# Altium schematic sheet Power.SchDoc(Power)
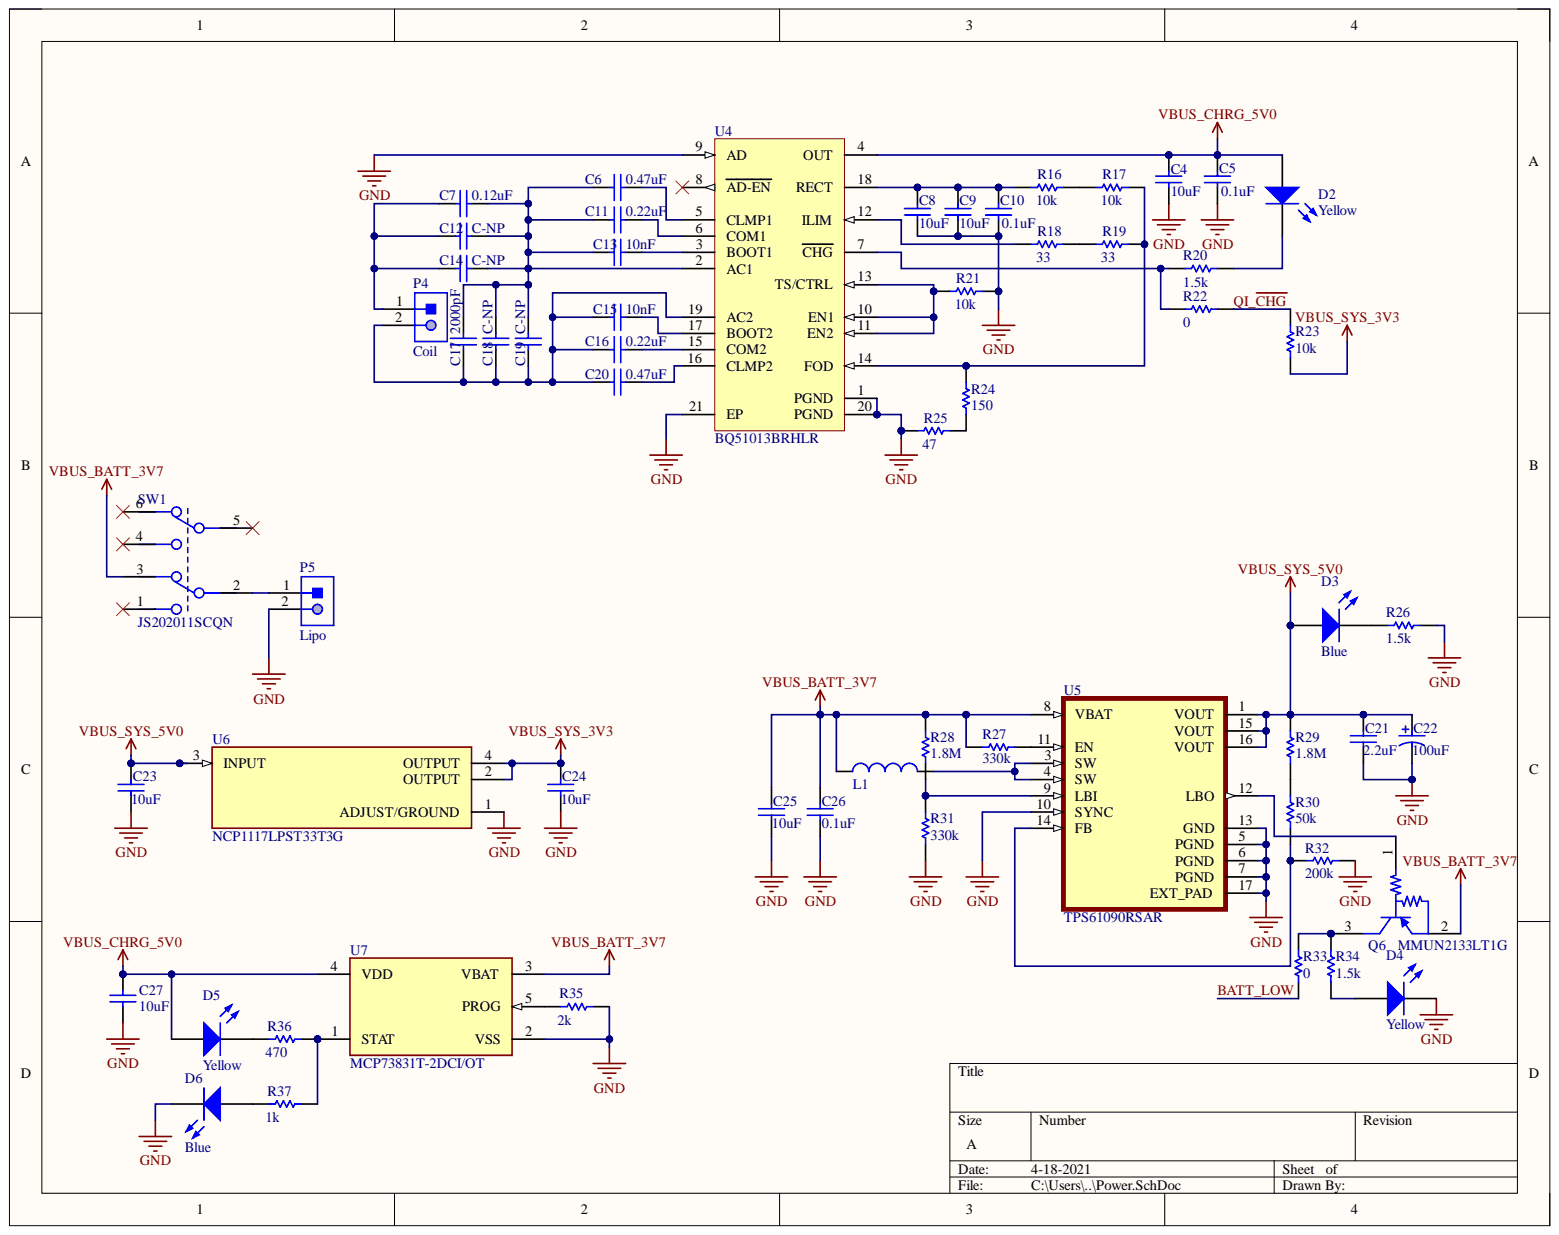
[diagram p0: Power.SchDoc]

## Parts
C4  10uF  [0603]
C5  0.1uF  [0603]
C6  0.47uF  [0603]
C7  0.12uF  [0603]
C8  10uF  [0603]
C9  10uF  [0603]
C10  0.1uF  [0603]
C11  0.22uF  [0603]
C12  C-NP  [0603]
C13  10nF  [0603]
C14  C-NP  [0603]
C15  10nF  [0603]
C16  0.22uF  [0603]
C17  2000pF  [0603]
C18  C-NP  [0603]
C19  C-NP  [0603]
C20  0.47uF  [0603]
C21  2.2uF  [0805]
C22  100uF  [PNSC-FP-V-C_V]
C23  10uF  [0805]
C24  10uF  [0805]
C25  10uF  [0805]
C26  0.1uF  [0805]
C27  10uF  [0805]
D2  Yellow  [1206_A_LED]
D3  Blue  [1206_A_LED]
D4  Yellow  [1206_A_LED]
D5  Yellow  [1206_A_LED]
D6  Blue  [1206_A_LED]
L1  MSS6132-472MLB  [COILCRAFT MSS6132 SERIES]  (value not drawn)
P4  Coil  [JST-S2B-PH-K-S_V]
P5  Lipo  [JST-S2B-PH-K-S_V]
Q6  MMUN2133LT1G  [ONSC-SOT-23-3-318-08_V]
R16  10k  [0603_RES_HD]
R17  10k  [0603_RES_HD]
R18  33  [0603_RES_HD]
R19  33  [0603_RES_HD]
R20  1.5k  [0603_RES_HD]
R21  10k  [0603_RES_HD]
R22  0  [0603_RES_HD]
R23  10k  [0603_RES_HD]
R24  150  [0603_RES_HD]
R25  47  [0603_RES_HD]
R26  1.5k  [0805_RES_HD]
R27  330k  [0805_RES_HD]
R28  1.8M  [0805_RES_HD]
R29  1.8M  [0805_RES_HD]
R30  50k  [0805_RES_HD]
R31  330k  [0805_RES_HD]
R32  200k  [0805_RES_HD]
R33  0  [0805_RES_HD]
R34  1.5k  [0805_RES_HD]
R35  2k  [0805_RES_HD]
R36  470  [0805_RES_HD]
R37  1k  [0805_RES_HD]
SW1  JS202011SCQN  [FP-JS202011SCQN-MFG]
U4  BQ51013BRHLR  [RHL0020A_V]
U5  TPS61090RSAR  [QFN65P400X400X100-17N]
U6  NCP1117LPST33T3G  [FP-318H-MFG]
U7  MCP73831T-2DCI/OT  [FP-C04-091-OT-IPC_B]

## Nets
GND: C4.1 C5.1 C8.1 C9.1 C10.1 C21.2 C22.2 C23.2 C24.2 C25.2 C26.2 C27.2 D4.1 D6.1 P5.2 R21.2 R25.1 R26.1 R31.1 R32.2 R35.2 U4.1(PGND) U4.9(AD) U4.20(PGND) U4.21(EP) U5.5(PGND) U5.6(PGND) U5.7(PGND) U5.10(SYNC) U5.13(GND) U5.17(EXT_PAD) U6.1(ADJUST/GROUND) U7.2(VSS)
VBUS_BATT_3V7: C25.1 C26.1 L1.1 Q6.2 R27.1 R28.2 SW1.3 U5.8(VBAT) U7.3(VBAT)
VBUS_CHRG_5V0: C4.2 C5.2 C27.1 D2.2 D5.2 U4.4(OUT) U7.4(VDD)
VBUS_SYS_3V3: C24.1 R23.1 U6.2(OUTPUT) U6.4(OUTPUT)
VBUS_SYS_5V0: C21.1 C22.1 C23.1 D3.2 R29.2 U5.1(VOUT) U5.15(VOUT) U5.16(VOUT) U6.3(INPUT)
BATT_LOW: R33.1
QI_~{CHG}: R22.1 R23.2
NetC6_1: C6.1 U4.5(CLMP1)
NetC6_2: C6.2 C7.1 C11.2 C12.1 C13.2 C14.1 C17.1 C18.1 C19.1 U4.2(AC1)
NetC7_2: C7.2 C12.2 C14.2 P4.1
NetC8_2: C8.2 C9.2 C10.2 R16.1 U4.18(RECT)
NetC11_1: C11.1 U4.6(COM1)
NetC13_1: C13.1 U4.3(BOOT1)
NetC15_1: C15.1 U4.17(BOOT2)
NetC15_2: C15.2 C16.2 C17.2 C18.2 C19.2 C20.2 P4.2 U4.19(AC2)
NetC16_1: C16.1 U4.15(COM2)
NetC20_1: C20.1 U4.16(CLMP2)
NetD2_1: D2.1 R20.1
NetD3_1: D3.1 R26.2
NetD4_2: D4.2 R34.1
NetD5_1: D5.1 R36.1
NetD6_2: D6.2 R37.1
NetL1_2: L1.2 U5.3(SW) U5.4(SW)
NetP5_1: P5.1 SW1.2
NetQ6_1: Q6.1 U5.12(LBO)
NetQ6_3: Q6.3 R33.2 R34.2
NetR16_2: R16.2 R17.1
NetR17_2: R17.2 R19.2 R24.2 U4.14(FOD)
NetR18_1: R18.1 U4.12(ILIM)
NetR18_2: R18.2 R19.1
NetR20_2: R20.2 R22.2 U4.7(CHG)
NetR21_1: R21.1 U4.10(EN1) U4.11(EN2) U4.13(TS/CTRL)
NetR24_1: R24.1 R25.2
NetR27_2: R27.2 U5.11(EN)
NetR28_1: R28.1 R31.2 U5.9(LBI)
NetR29_1: R29.1 R30.1
NetR30_2: R30.2 R32.1 U5.14(FB)
NetR35_1: R35.1 U7.5(PROG)
NetR36_2: R36.2 R37.2 U7.1(STAT)

Not connected: SW1 1 4 5 6; U4 8(AD-EN)

## Library data of the parts
C4 C8 C9 = C1608C0G1H361J: 10uF, 10%, 25V; Manufacturer 1: TDK; Database Table Name: capacitors; Component Kind: Standard; GFUPartNo: C1608C0G1H361J; Manufacturer Part Number 1: C1608C0G1H361J; PackageReference: 0603; Part Type: SMT; Supplier 1: Digi-Key; Supplier Part Number 1: 445-5067-1-ND; Library Name: AltiumGFU.SVNDbLib
C5 C10 = C1608C0G1H361J: 0.1uF, 10%, 50V; Manufacturer 1: TDK; Database Table Name: capacitors; Component Kind: Standard; GFUPartNo: C1608C0G1H361J; Manufacturer Part Number 1: C1608C0G1H361J; PackageReference: 0603; Part Type: SMT; Supplier 1: Digi-Key; Supplier Part Number 1: 445-5067-1-ND; Library Name: AltiumGFU.SVNDbLib
C6 C20 = C1608C0G1H361J: 0.47uF, 10%, 25V; Manufacturer 1: TDK; Database Table Name: capacitors; Component Kind: Standard; GFUPartNo: C1608C0G1H361J; Manufacturer Part Number 1: C1608C0G1H361J; PackageReference: 0603; Part Type: SMT; Supplier 1: Digi-Key; Supplier Part Number 1: 445-5067-1-ND; Library Name: AltiumGFU.SVNDbLib
C7 C12 C14 = C1608C0G1H361J: 0.12 uF, 10%, 25V; Manufacturer 1: TDK; Database Table Name: capacitors; Component Kind: Standard; GFUPartNo: C1608C0G1H361J; Manufacturer Part Number 1: C1608C0G1H361J; PackageReference: 0603; Part Type: SMT; Supplier 1: Digi-Key; Supplier Part Number 1: 445-5067-1-ND; Library Name: AltiumGFU.SVNDbLib
C11 C16 = C1608C0G1H361J: 0.22uF, 10%, 25V; Manufacturer 1: TDK; Database Table Name: capacitors; Component Kind: Standard; GFUPartNo: C1608C0G1H361J; Manufacturer Part Number 1: C1608C0G1H361J; PackageReference: 0603; Part Type: SMT; Supplier 1: Digi-Key; Supplier Part Number 1: 445-5067-1-ND; Library Name: AltiumGFU.SVNDbLib
C13 C15 = C1608C0G1H361J: 10nF, 10%, 25V; Manufacturer 1: TDK; Database Table Name: capacitors; Component Kind: Standard; GFUPartNo: C1608C0G1H361J; Manufacturer Part Number 1: C1608C0G1H361J; PackageReference: 0603; Part Type: SMT; Supplier 1: Digi-Key; Supplier Part Number 1: 445-5067-1-ND; Library Name: AltiumGFU.SVNDbLib
C17-C19 = C1608C0G1H361J: 2000 pF, 10%, 50V; Manufacturer 1: TDK; Database Table Name: capacitors; Component Kind: Standard; GFUPartNo: C1608C0G1H361J; Manufacturer Part Number 1: C1608C0G1H361J; PackageReference: 0603; Part Type: SMT; Supplier 1: Digi-Key; Supplier Part Number 1: 445-5067-1-ND; Library Name: AltiumGFU.SVNDbLib
C21 C23-C27 = CC0805KRX7R9BB152: 1.5nF, 10%, 50V; Manufacturer 1: Yageo; Database Table Name: capacitors; Component Kind: Standard; GFUPartNo: CC0805KRX7R9BB152; Manufacturer Part Number 1: CC0805KRX7R9BB152; PackageReference: 0805; Part Type: SMT; Supplier 1: Digi-Key; Supplier Part Number 1: 311-1128-1-ND; Library Name: AltiumGFU.SVNDbLib
C22 = CMP-2000-06213-1: EEE-HA Series 6.3 V 100 uF Ø 5 x 5.4 mm 105 °C SMT V-Chip Aluminum Electrolytic. EEE-HA0J101WR; Manufacturer: Panasonic; Capacitance: 22µF; ESR (Equivalent Series Resistance): 0.36O; Lifetime@Temperature: 2000Hrs@105°C; Rated Voltage: 25V; Ripple Current: 240mA; Type: Can; Case Size/Dimension: Ø5x5.8mm; Component Kind: Standard; Datasheet URL: http://industrial.panasonic.com/cdbs/www-data/pdf/RDE0000/ABA0000C1184.pdf; Datasheet Version: 03/2016; Library Name: Altium Content Vault; Manufacturer URL: http://www.panasonic.com/; Mounting Technology: SurfaceMount; Operating Temp Range: -55to+105°C; Operating Temp-Max: 105°C; Package Description: 2-Pin Electrolytic Aluminum Capacitor, Body 5.3 x 5.3 mm; Package Reference: FP-V-C; Package URL: http://industrial.panasonic.com/cdbs/www-data/pdf/RDE0000/DME0000COL48.pdf; Series: FP
D2-D6 = WE-LED_K1A2-2_Local: Component Kind: Standard; Library Name: WE-LED_K1A2-2.SchLib
L1 = MSS6132-472MLB: INDUCTOR POWER 4.7UH SHIELDED SMD; Manufacturer 1: Coilcraft; Current: 2.3A; Database Table Name: inductors; Shielded: YES; Tolerance: 20%; Component Kind: Standard; GFUPartNo: MSS6132-472MLB; Inductance: 4.7uH; Manufacturer Part Number 1: MSS6132-472MLB; Part Type: SMT; Supplier 1: Coilcraft; Supplier 2: Coilcraft; Supplier Order Qty 1: 1; Supplier Order Qty 2: 100; Supplier Part Number 1: MSS6132-472MLB; Supplier Part Number 2: MSS6132-472MLB; Supplier Unit Price 1: 0.94; Supplier Unit Price 2: 0.94; Library Name: AltiumGFU.SVNDbLib
P4 P5 = CMP-1755-00004-1: Male Header, Pitch 2 mm, 1 x 2 Position, Height 4.8 mm, Tail Length 3.4 mm, -25 to 85 degC, RoHS, Bulk; Capacitance: 10 uF; Case\Package: Radial; Tolerance: 20%; Voltage Rating: 50 V; Component Kind: Standard; ComponentLink1Description: Datasheet; ComponentLink1URL: http://www.jst-mfg.com/product/pdf/eng/ePH.pdf; DatasheetVersion: Dec-12; Diameter: 5 mm; Height: 11 mm; Lead Pitch: 2 mm; Length: 11 mm; Library Name: Altium Content Vault; Max Operating Temperature: 85 degC; Min Operating Temperature: -40 degC; Mount: Through Hole; Package Quantity: 200; PackageDescription: Male Header, Pitch 2 mm, 1 x 2 Position, Height 4.8 mm, Tail Length 3.4 mm; PackageReference: S2B-PH-K-S; Packaging: Bulk; Pins: 2; Radiation Hardening: No; REACH SVHC: No SVHC; RoHSCompliant: true; Voltage Rating (DC): 50 V
Q6 = CMP-0630-00284-1: Digital Transistor (BRT), R1=4.7 kOhm, R2=47 kOhm, PNP Transistor, 3-Pin SOT-23, Pb-Free, Tape and Reel; Manufacturer: On Semiconductor; hFE Min: 80; IC Continuous (A): 0.1; V(BR)CEO Min (V): 50; Component Kind: Standard; ComponentLink1Description: Manufacturer URL; ComponentLink1URL: http://www.onsemi.com/; ComponentLink2Description: Datasheet; ComponentLink2URL: http://www.onsemi.cn/pub_link/Collateral/DTA143Z-D.PDF; ComponentLink3Description: Package Specification; ComponentLink3URL: http://www.onsemi.cn/pub_link/Collateral/318-08.PDF; DatasheetVersion: Rev. 6; Library Name: Altium Content Vault; Mounting Technology: Surface Mount; PackageDescription: 3-Pin Small Outline Transistor, Body 2.92 x 1.3 mm, Pitch 0.95 mm; PackageReference: SOT-23-3-318-08; PackageVersion: Rev. AP, 11/2009; Packing: Tape and Reel; PartNumber: MMUN2133LT1G; Polarity: PNP; R1/R2 Typ: 0.1; RoHS: TRUE
R16 R17 R21 = RC0603JR-0718RL: RES 10k OHM 1/10W 5% 0603 SMD; Manufacturer 1: Yageo; Database Table Name: resistors; Component Kind: Standard; GFUPartNo: RC0603JR-0718RL; Manufacturer Part Number 1: RC0603JR-0718RL; Part Type: SMT; Signal Integrity: Res; Simulation: RESISTOR; Supplier 1: Digi-Key; Supplier Part Number 1: 311-18GRCT-ND; Library Name: AltiumGFU.SVNDbLib
R18 R19 = RC0603JR-0718RL: RES 33 OHM 1/10W 5% 0603 SMD; Manufacturer 1: Yageo; Database Table Name: resistors; Component Kind: Standard; GFUPartNo: RC0603JR-0718RL; Manufacturer Part Number 1: RC0603JR-0718RL; Part Type: SMT; Signal Integrity: Res; Simulation: RESISTOR; Supplier 1: Digi-Key; Supplier Part Number 1: 311-18GRCT-ND; Library Name: AltiumGFU.SVNDbLib
R20 R22 R23 = RC0603JR-0718RL: RES 1.5k OHM 1/10W 5% 0603 SMD; Manufacturer 1: Yageo; Database Table Name: resistors; Component Kind: Standard; GFUPartNo: RC0603JR-0718RL; Manufacturer Part Number 1: RC0603JR-0718RL; Part Type: SMT; Signal Integrity: Res; Simulation: RESISTOR; Supplier 1: Digi-Key; Supplier Part Number 1: 311-18GRCT-ND; Library Name: AltiumGFU.SVNDbLib
R24 = RC0603JR-0718RL: RES 150 OHM 1/10W 5% 0603 SMD; Manufacturer 1: Yageo; Database Table Name: resistors; Component Kind: Standard; GFUPartNo: RC0603JR-0718RL; Manufacturer Part Number 1: RC0603JR-0718RL; Part Type: SMT; Signal Integrity: Res; Simulation: RESISTOR; Supplier 1: Digi-Key; Supplier Part Number 1: 311-18GRCT-ND; Library Name: AltiumGFU.SVNDbLib
R25 = RC0603JR-0718RL: RES 47 OHM 1/10W 5% 0603 SMD; Manufacturer 1: Yageo; Database Table Name: resistors; Component Kind: Standard; GFUPartNo: RC0603JR-0718RL; Manufacturer Part Number 1: RC0603JR-0718RL; Part Type: SMT; Signal Integrity: Res; Simulation: RESISTOR; Supplier 1: Digi-Key; Supplier Part Number 1: 311-18GRCT-ND; Library Name: AltiumGFU.SVNDbLib
R26 R33 R34 = RC0805FR-07100RL: 1.5kOhm ±1% 0.125W, 1/8W Surface Mount Resistor Thick Film ±100ppm/°C 0805; Manufacturer 1: Yageo; Database Table Name: resistors; Component Kind: Standard; GFUPartNo: RC0805FR-07100RL; Manufacturer Part Number 1: RC0805FR-07100RL; Part Type: SMT; Signal Integrity: Res; Simulation: RESISTOR; Supplier 1: Digi-Key; Supplier Part Number 1: 311-100CRCT-ND; Library Name: AltiumGFU.SVNDbLib
R27 R31 = RC0805FR-07100RL: 330kOhm ±1% 0.125W, 1/8W Surface Mount Resistor Thick Film ±100ppm/°C 0805; Manufacturer 1: Yageo; Database Table Name: resistors; Component Kind: Standard; GFUPartNo: RC0805FR-07100RL; Manufacturer Part Number 1: RC0805FR-07100RL; Part Type: SMT; Signal Integrity: Res; Simulation: RESISTOR; Supplier 1: Digi-Key; Supplier Part Number 1: 311-100CRCT-ND; Library Name: AltiumGFU.SVNDbLib
R28 = RC0805FR-07100RL: 1.8MOhm ±1% 0.125W, 1/8W Surface Mount Resistor Thick Film ±100ppm/°C 0805; Manufacturer 1: Yageo; Database Table Name: resistors; Component Kind: Standard; GFUPartNo: RC0805FR-07100RL; Manufacturer Part Number 1: RC0805FR-07100RL; Part Type: SMT; Signal Integrity: Res; Simulation: RESISTOR; Supplier 1: Digi-Key; Supplier Part Number 1: 311-100CRCT-ND; Library Name: AltiumGFU.SVNDbLib
R29 = RC0805FR-07100RL: 100 Ohm ±1% 0.125W, 1/8W Surface Mount Resistor Thick Film ±100ppm/°C 0805; Manufacturer 1: Yageo; Database Table Name: resistors; Component Kind: Standard; GFUPartNo: RC0805FR-07100RL; Manufacturer Part Number 1: RC0805FR-07100RL; Part Type: SMT; Signal Integrity: Res; Simulation: RESISTOR; Supplier 1: Digi-Key; Supplier Part Number 1: 311-100CRCT-ND; Library Name: AltiumGFU.SVNDbLib
R30 R32 = RC0805FR-07100RL: 50k Ohm ±1% 0.125W, 1/8W Surface Mount Resistor Thick Film ±100ppm/°C 0805; Manufacturer 1: Yageo; Database Table Name: resistors; Component Kind: Standard; GFUPartNo: RC0805FR-07100RL; Manufacturer Part Number 1: RC0805FR-07100RL; Part Type: SMT; Signal Integrity: Res; Simulation: RESISTOR; Supplier 1: Digi-Key; Supplier Part Number 1: 311-100CRCT-ND; Library Name: AltiumGFU.SVNDbLib
R35 = RC0805FR-07100RL: 20kOhm ±1% 0.125W, 1/8W Surface Mount Resistor Thick Film ±100ppm/°C 0805; Manufacturer 1: Yageo; Database Table Name: resistors; Component Kind: Standard; GFUPartNo: RC0805FR-07100RL; Manufacturer Part Number 1: RC0805FR-07100RL; Part Type: SMT; Signal Integrity: Res; Simulation: RESISTOR; Supplier 1: Digi-Key; Supplier Part Number 1: 311-100CRCT-ND; Library Name: AltiumGFU.SVNDbLib
R36 R37 = RC0805FR-07100RL: 470Ohm ±1% 0.125W, 1/8W Surface Mount Resistor Thick Film ±100ppm/°C 0805; Manufacturer 1: Yageo; Database Table Name: resistors; Component Kind: Standard; GFUPartNo: RC0805FR-07100RL; Manufacturer Part Number 1: RC0805FR-07100RL; Part Type: SMT; Signal Integrity: Res; Simulation: RESISTOR; Supplier 1: Digi-Key; Supplier Part Number 1: 311-100CRCT-ND; Library Name: AltiumGFU.SVNDbLib
SW1 = CMP-2000-05087-2: SWITCH SLIDE DPDT 300MA 6V; Actuator Length: 2mm; Actuator Material: Nylon; Actuator Type: Standard; Contact Resistance: 70mR; Electrical Life: 5000Cycles; Switch Function: On-On; Component Kind: Standard; Contact Current Rating: 300mA; Contact Material: Copper Alloy; Contact Plating: Silver; Current Rating: 300mA; Depth: 7.5mm; Flammability Rating: UL94 V-2; Housing Material: Nylon; Lead Free: Lead Free; Length: 9mm; Library Name: Altium Content Vault; Max Current Rating: 300mA; Max Operating Temperature: 85°C; Max Voltage Rating (DC): 6V; Min Operating Temperature: -20°C; Mount: Surface Mount; Orientation: Vertical; Package Quantity: 1; Packaging: Tape and Reel; Radiation Hardening: No; REACH SVHC: No SVHC; Switch Travel: 2mm; Termination: Gull Wing; Throw Configuration: DPDT; Voltage Rating (DC): 6V
U4 = CMP-0393-00005-2: WPC 1.1 Compatible Fully Integrated Wireless Power Receiver IC, 4 V Min Input, 20-pin VQFN (RHL), Green (RoHS & no Sb/Br); Eco-Plan: TRUE; Vin(Min)(V): 4; Component Kind: Standard; ComponentLink1Description: Manufacturer URL; ComponentLink1URL: http://www.ti.com/; ComponentLink2Description: Datasheet; ComponentLink2URL: http://www.ti.com/lit/ds/symlink/bq51013b.pdf; ComponentLink3Description: Package Specification; ComponentLink3URL: http://www.ti.com/lit/ds/symlink/bq51051b.pdf; DatasheetVersion: SLUSB62; Library Name: Altium Content Vault; Mounting Technology: Surface Mount; PackageDescription: 20-Pin Plastic Flat Pack, Body 4.5 x 3.5 mm, Pitch 0.5 mm; PackageReference: RHL0020A; PackageVersion: 4205346-3/J, 12/2011; PartNumber: BQ51013B
U5 = TPS61090RSAR: 1.8Vin, 2A Switch, 96% Efficient Boost Converter 16-QFN -40 to 85; MF: Texas Instruments; Availability: Good; Check_prices: https://www.snapeda.com/parts/TPS61090RSAR/Texas%20Instruments/view-part/51689/?ref=eda; Component Kind: Standard; Library Name: TPS61090RSAR.SchLib; MP: TPS61090RSAR; Package: VQFN-16 Texas Instruments; Price: None; Purchase-URL: https://pricing.snapeda.com/search/part/TPS61090RSAR/?ref=eda; SnapEDA_Link: https://www.snapeda.com/parts/TPS61090RSAR/Texas%20Instruments/view-part/51689/?ref=snap
U6 = CMP-07128-000031-1: IC REG LINEAR 3.3V 1A SOT223; Dropout Voltage: 1.3V; Max Input Voltage: 18V; Min Current Limit: 1.1A; Min Input Voltage: -300mV; Number of Outputs: 1; Output Type: Fixed; Polarity: Positive; Power Supply Rejection Ratio (PSRR): 60dB; Quiescent Current: 700uA; Reference Voltage: 1.275V; Case/Package: SOT-223; Component Kind: Standard; Contact Plating: Tin; Halogen Free: Halogen Free; Height: 1.69mm; Lead Free: Lead Free; Length: 6.7mm; Library Name: Altium Content Vault; Max Operating Temperature: 125°C; Max Output Current: 1A; Min Operating Temperature: 0°C; Min Output Voltage: 3.3V; Mount: Surface Mount; Nominal Output Voltage: 3.3V; Number of Pins: 3; Number of Regulators: 1; Output Current: 1A; Output Voltage: 3.3V; Package Quantity: 1; Packaging: Tape and Reel; REACH SVHC: No SVHC; Width: 3.7mm
U7 = CMP-0133-00146-2: IC CONTROLLR LI-ION 4.2V SOT23-5; Max Charge Current: 500mA; Max Input Voltage: 3.75V; Max Output Voltage: 4.232V; Max Supply Voltage: 6V; Min Output Voltage: 4.168V; Number of Cells: 1; Operating Supply Current: 510uA; Case/Package: SOT-23; Component Kind: Standard; Contact Plating: Tin; Height: 1.3mm; Lead Free: Lead Free; Length: 3.1mm; Library Name: Altium Content Vault; Max Operating Temperature: 85°C; Max Output Current: 500mA; Min Operating Temperature: -40°C; Min Supply Voltage: 3.75V; Mount: Surface Mount; Number of Channels: 1; Number of Pins: 5; Output Current: 500mA; Output Voltage: 4.2V; Package Quantity: 3000; Packaging: Tape and Reel; Radiation Hardening: No; REACH SVHC: No SVHC; Width: 1.8mm
